# Altium schematic sheet Motor Control.SchDoc
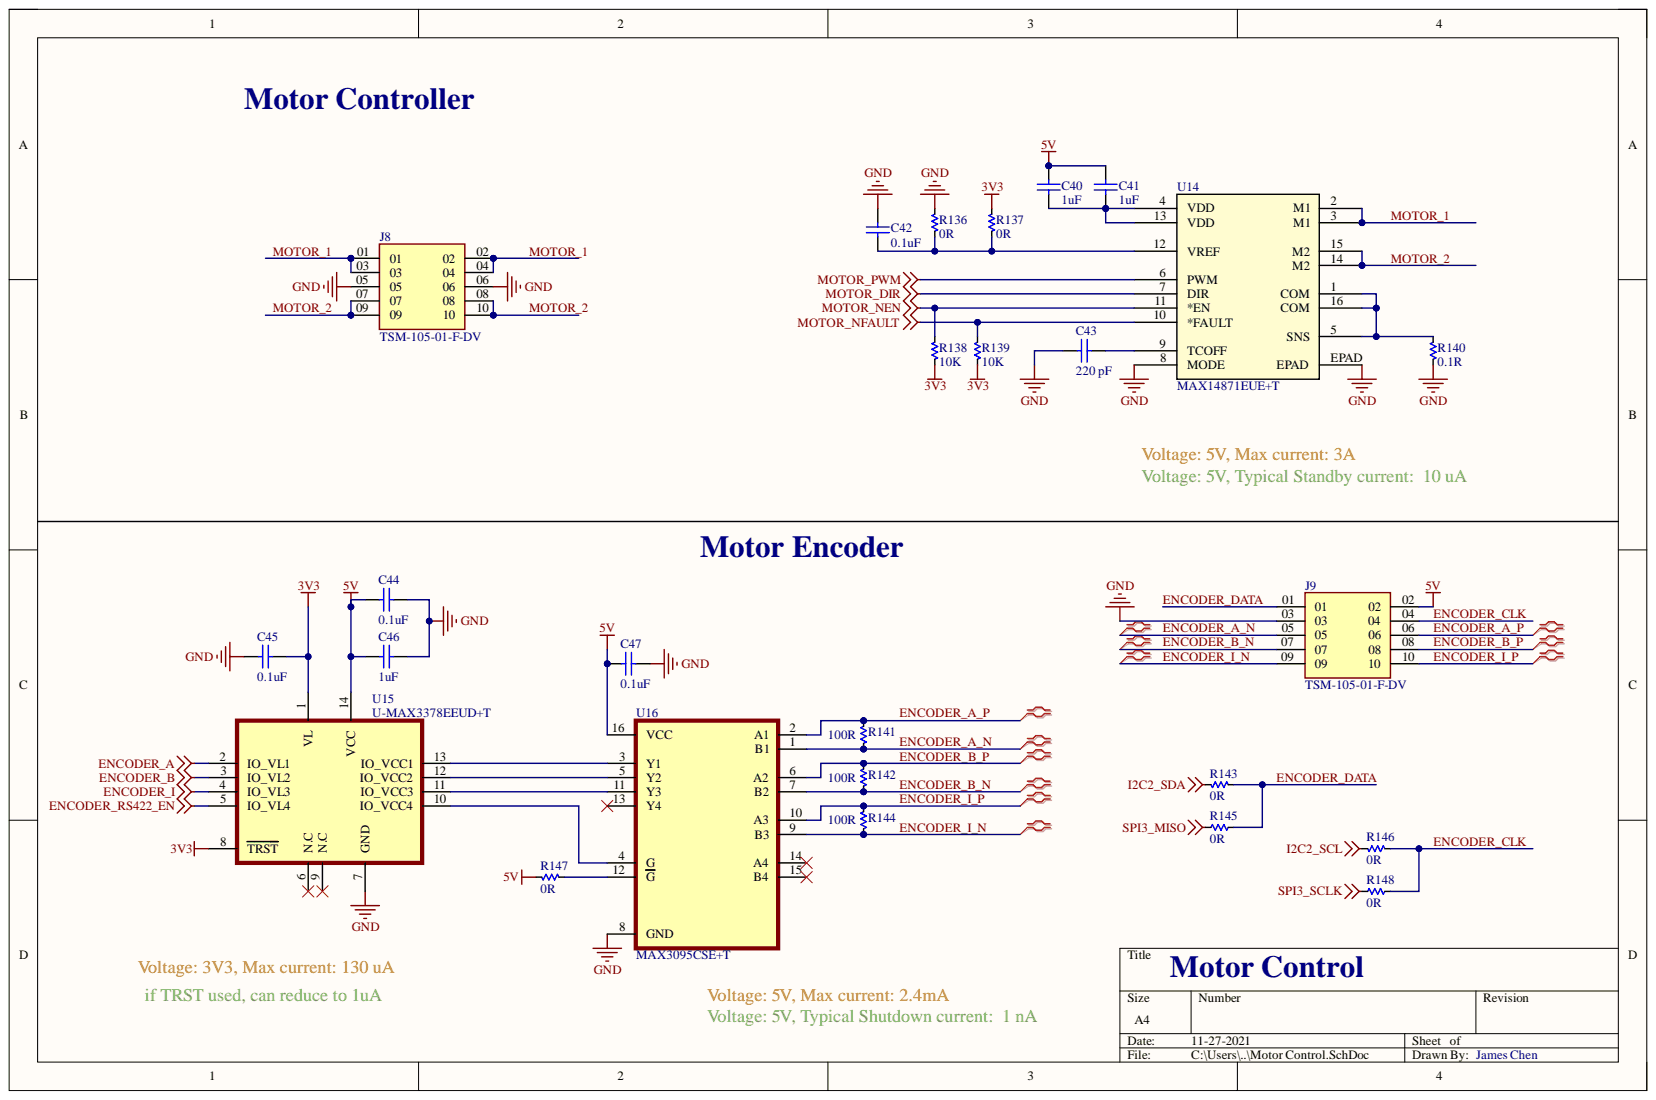
[diagram p0: Motor Control.SchDoc]

## Parts
C40  1uF  [0603]
C41  1uF  [0603]
C42  0.1uF  [0402]
C43  220 pF  [0402]
C44  0.1uF  [0402]
C45  0.1uF  [0402]
C46  1uF  [0603]
C47  0.1uF  [0402]
J8  TSM-105-01-F-DV  [J-TSM-105-01-F-DV]
J9  TSM-105-01-F-DV  [J-TSM-105-01-F-DV]
R136  0R  [0402]
R137  0R  [0402]
R138  10K  [0402]
R139  10K  [0402]
R140  0.1R  [2512]
R141  100R  [0402]
R142  100R  [0402]
R143  0R  [0402]
R144  100R  [0402]
R145  0R  [0402]
R146  0R  [0402]
R147  0R  [0402]
R148  0R  [0402]
U14  MAX14871EUE+T  [U-MAX14871EUE+T]
U15  U-MAX3378EEUD+T  [U-MAX3378EEUD+T]
U16  MAX3095CSE+T  [U-MAX3095CSE+T]

## Nets
3V3: C45.1 R137.2 R138.1 R139.1 U15.1(VL) U15.8(TRST)
5V: C40.2 C41.2 C44.1 C46.2 C47.1 J9.02 R147.2 U15.14(VCC) U16.16(VCC)
ENCODER_A: U15.2(IO_VL1)
ENCODER_B: U15.3(IO_VL2)
ENCODER_I: U15.4(IO_VL3)
ENCODER_RS422_EN: U15.5(IO_VL4)
GND: C42.2 C43.1 C44.2 C45.2 C46.1 C47.2 J8.05 J8.06 J9.03 R136.2 R140.1 U14.8(MODE) U14.EPAD U15.7(GND) U16.8(GND)
I2C2_SCL: R146.2
I2C2_SDA: R143.2
MOTOR_DIR: U14.7(DIR)
MOTOR_NEN: R138.2 U14.11(*EN)
MOTOR_NFAULT: R139.2 U14.10(*FAULT)
MOTOR_PWM: U14.6(PWM)
SPI3_MISO: R145.1
SPI3_SCLK: R148.1
ENCODER_A_N: J9.05 R141.1 U16.1(B1)
ENCODER_A_P: J9.06 R141.2 U16.2(A1)
ENCODER_B_N: J9.07 R142.1 U16.7(B2)
ENCODER_B_P: J9.08 R142.2 U16.6(A2)
ENCODER_CLK: J9.04 R146.1 R148.2
ENCODER_DATA: J9.01 R143.1 R145.2
ENCODER_I_N: J9.09 R144.1 U16.9(B3)
ENCODER_I_P: J9.10 R144.2 U16.10(A3)
MOTOR_1: J8.01 J8.02 J8.03 J8.04 U14.2(M1) U14.3(M1)
MOTOR_2: J8.07 J8.08 J8.09 J8.10 U14.14(M2) U14.15(M2)
NetC40_1: C40.1 C41.1 U14.4(VDD) U14.13(VDD)
NetC42_1: C42.1 R136.1 R137.1 U14.12(VREF)
NetC43_2: C43.2 U14.9(TCOFF)
NetR140_2: R140.2 U14.1(COM) U14.5(SNS) U14.16(COM)
NetR147_1: R147.1 U16.12(G)
NetU15_10: U15.10(IO_VCC4) U16.4(G)
NetU15_11: U15.11(IO_VCC3) U16.11(Y3)
NetU15_12: U15.12(IO_VCC2) U16.5(Y2)
NetU15_13: U15.13(IO_VCC1) U16.3(Y1)

Not connected: U15 6(N.C) 9(N.C); U16 13(Y4) 14(A4) 15(B4)

## Library data of the parts
C40 C41 C46 = CC-105-K-25V-X7R-0603: CAP CER 1UF 25V X7R 0603; Manufacturer: Yageo; Manufacturer Part #: CC0603KRX7R8BB105; Component Kind: Standard; ComponentLink1Description: Datasheet; ComponentLink1URL: https://www.yageo.com/upload/media/product/productsearch/datasheet/mlcc/UPY-GPHC_X7R_6.3V-to-50V_18.pdf; ComponentLink2Description: Manufacture Website; ComponentLink2URL: https://www.yageo.com/en/Home; Distributor: Digikey; Distributor Part #: 311-1802-1-ND; Part Description: CAP CER 1UF 25V X7R 0603; ROHS: Yes; SignalCraft Part #: CC-105-K-25V-X7R-0603; Value: 1uF; Library Name: OBC Development Board.SchLib
C42 C44 C45 C47 = CC-104-K-25V-X7R-0402: CAP CER 0.1UF 25V X7R 0402; Manufacturer: YAGEO; Manufacturer Part #: CC0402KPX7R8BB104; Component Kind: Standard; ComponentLink1description: Datasheet; ComponentLink1URL: https://ds.yuden.co.jp/TYCOMPAS/ut/detail?pn=TMK105BJ104KV-F%20%20&u=M; ComponentLink2description: Manufacturer Website; ComponentLink2URL: https://www.yuden.co.jp/or/; Distributor: Digikey; Distributor Part #: 13-CC0402KPX7R8BB104CT-ND; Part Description: CAP CER 0.1UF 25V X7R 0402; ROHS: Yes; Value: 0.1uF; Library Name: OBC Development Board.SchLib
C43 = CC-228-J-50V-C0G-0402: CAP CER 220PF 50V X7R 0402; Manufacturer: YAGEO; Manufacturer Part #: CC0402KRX7R9BB221; Component Kind: Standard; ComponentLink1Description: Datasheet; ComponentLink2Description: Manufacture Website; Distributor: Digikey; Distributor Part #: 311-1035-1-ND; Part Description: CAP CER 220PF 50V X7R 0402; Value: 220 pF; Library Name: OBC Development Board.SchLib
J8 J9 = J-TSM-105-01-F-DV: CONN HEADER SMD 10POS 2.54MM; Manufacturer: Samtec Inc.; Component Kind: Standard; Distributor: Digikey; Distributor Part #: SAM12227-ND; Manufacturer Part #: TSM-105-01-F-DV; Library Name: OBC Development Board.SchLib
R136 R137 R143 R145-R148 = RT-0R00-F-1/10W-0402: RES SMD 0 OHM JUMPER 1/10W 0402; Alternate Manufacturer: Yageo; Alternate Manufacturer Part #: RC0402JR-070RL; Manufacturer: Panasonic Electronic Components; Manufacturer Part #: ERJ-2GE0R00X; Alternate Distributor Part #: 311-0.0JRCT-ND; Component Kind: Standard; ComponentLink1description: Datasheet; ComponentLink1URL: https://industrial.panasonic.com/ww/products/resistors/chip-resistors/general-purpose-chip-resistors/models/ERJ2GE0R00X; ComponentLink2description: Manufacturer Website; ComponentLink2URL: https://industrial.panasonic.com/ww/products/resistors/chip-resistors/general-purpose-chip-resistors/models/ERJ2GE0R00X; Distributor: Digikey; Distributor Part #: P0.0JCT-ND; Part Description: RES SMD 0 OHM JUMPER 1/10W 0402; ROHS: Yes; Value: 0R; Library Name: OBC Development Board.SchLib
R138 R139 = RT-10K0-F-1/16W-0402: RES SMD 10K OHM 1% 1/16W 0402; Alternate Manufacturer: Yageo; Alternate Manufacturer Part #: RC0402FR-0710KL; Manufacturer: Vishay Dale; Manufacturer Part #: CRCW040210K0FKED; Alternate Distributor Part #: 311-10.0KLRCT-ND; Component Kind: Standard; ComponentLink1description: Datasheet; ComponentLink1URL: https://www.vishay.com/docs/20035/dcrcwe3.pdf; ComponentLink2description: Manufacturer Website; ComponentLink2URL: https://www.vishay.com/; Distributor: Digikey; Distributor Part #: 541-10.0KLCT-ND; Part Description: RES SMD 10K OHM 1% 1/16W 0402; ROHS: Yes; Value: 10K; Library Name: OBC Development Board.SchLib
R140 = RT-0R1-3W-2512: RES 0.1 OHM 1% 3W 2512; Manufacturer: Bourns Inc.; Manufacturer Part #: CRA2512-FZ-R100ELF; Component Kind: Standard; ComponentLink1description: Datasheet; ComponentLink1URL: https://www.vishay.com/docs/20035/dcrcwe3.pdf; ComponentLink2description: Manufacturer Website; ComponentLink2URL: https://www.vishay.com/; Distributor: Digikey; Distributor Part #: CRA2512-FZ-R100ELFCT-ND; Part Description: RES 0.1 OHM 1% 3W 2512; Value: 0.1R; Library Name: OBC Development Board.SchLib
R141 R142 R144 = RT-100R-F-1/16W-0402: RES SMD 100 OHM 1% 1/16W 0402; Manufacturer: Yageo; Manufacturer Part #: RT0402FRE07100RL; Component Kind: Standard; Distributor: Digikey; Distributor Part #: YAG3342CT-ND; Part Description: RES SMD 100 OHM 1% 1/16W 0402; Value: 100R; Library Name: OBC Development Board.SchLib
U14 = U-MAX14871EUE+T: IC MOTOR DRIVER 4.5V-36V 16TSSOP; Manufacturer: Maxim Integrated Products; Component Kind: Standard; Distributor: Digikey; Distributor Part #: MAX14871EUE+CT-ND; Manufacturer Part #: MAX14871EUE+T; Library Name: OBC Development Board.SchLib
U15 = U-MAX3378EEUD+T: IC TRNSLTR BIDIRECTIONAL 14TSSOP; Manufacturer: Maxim Integrated; Component Kind: Standard; Distributor: Digikey; Distributor Part #: MAX3378EEUD+TCT-ND; Manufacturer Part #: MAX3378EEUD+T; Library Name: OBC Development Board.SchLib
U16 = U-MAX3095CSE+T: IC RECEIVER 0/4 16SO; Manufacturer: Maxim Integrated; Component Kind: Standard; Distributor: Digikey; Distributor Part #: MAX3095CSE+TCT-ND; Manufacturer Product #: MAX3095CSE+T; Library Name: OBC Development Board.SchLib
